# Altium schematic sheet Power.SchDoc(Power)
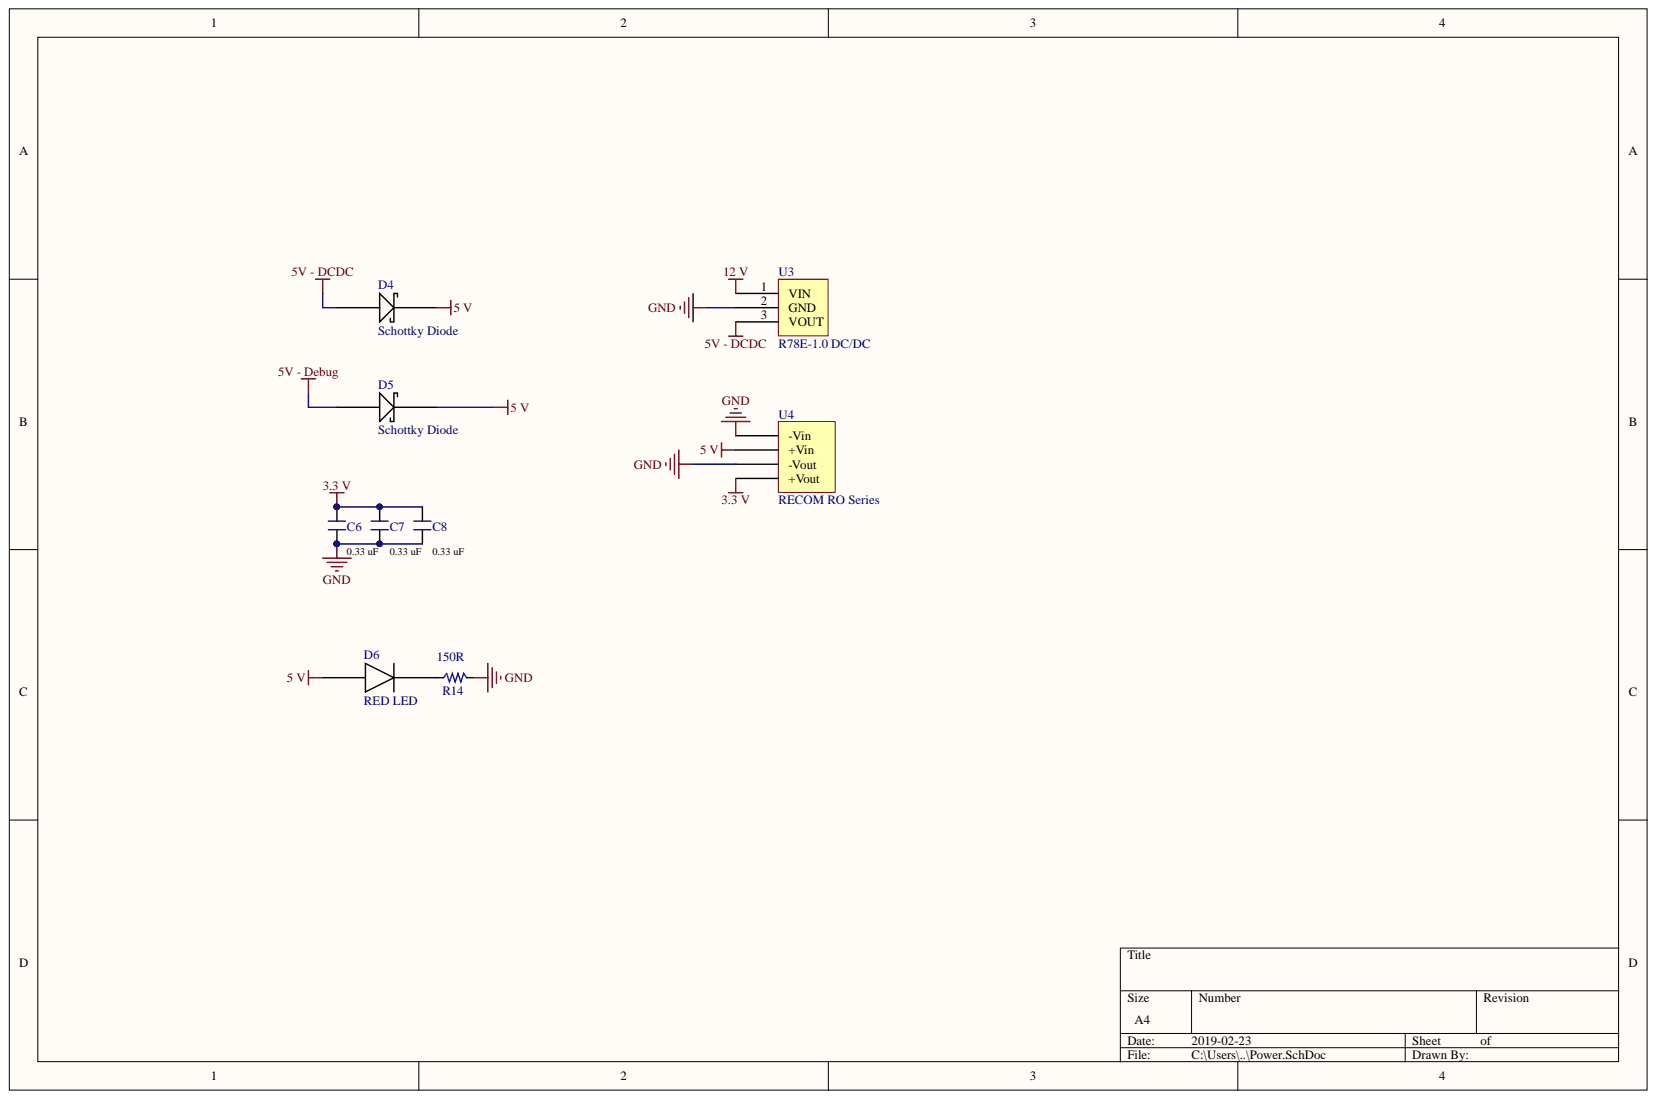
[diagram p0: Power.SchDoc]

## Parts
C6  SCH_GENERIC_CAPACITOR_0603  [PCB_GENERIC_SMD_0603]  (value not drawn)
C7  Capacitance: 0.33 uF  [PCB_GENERIC_SMD_0603]  (hidden comment: SCH_GENERIC_CAPACITOR_0603)
C8  Capacitance: 0.33 uF  [PCB_GENERIC_SMD_0603]  (hidden comment: SCH_GENERIC_CAPACITOR_0603)
D4  Schottky Diode  [ON Semi Schottky Diode - MBRM110LT1G]
D5  Schottky Diode  [ON Semi Schottky Diode - MBRM110LT1G]
D6  RED LED  [SMD_0603_LED]
R14  SCH_GENERIC_RESISTOR_0603  [PCB_GENERIC_SMD_0603]  (value not drawn)
U3  R78E-1.0 DC/DC  [R78-1.0]
U4  RECOM RO Series  [RECOM RO Series 1W DCDC]

## Nets
3.3 V: C6.2 C7.2 C8.2 U4.4(+Vout)
5 V: D4.1 D5.1 D6.1 U4.2(+Vin)
5V - DCDC: D4.2 U3.3
5V - Debug: D5.2
12 V: U3.1
GND: C6.1 C7.1 C8.1 R14.2 U3.2 U4.1(-Vin) U4.3(-Vout)
NetD6_2: D6.2 R14.1

## Library data of the parts
C6 = SCH_GENERIC_CAPACITOR_0603: Generic SMD capacitor 0603; Tolerance: *%; Capacitance: 0.33 uF; Library Name: Generic 0603 Capacitor.SchLib; Component Kind: Standard
C7 C8 = SCH_GENERIC_CAPACITOR_0603: Generic SMD capacitor 0603; Tolerance: *%; Library Name: Generic 0603 Capacitor.SchLib; Component Kind: Standard
D4 D5 = ON Semi Schottky Diode - MBRM110LT1G: Library Name: ON Semi Schottky Diode.SchLib; Component Kind: Standard
D6 = SMD_0603_LED: Library Name: 0603 LED.SchLib; Component Kind: Standard
R14 = SCH_GENERIC_RESISTOR_0603: Generic SMD resistor 0603; Library Name: SCH_GENERIC_RESISTOR_0603.SchLib; Component Kind: Standard
U3 = R78E-1.0: Component Kind: Standard; Library Name: Recom R78E-1.0.SchLib
U4 = RECOM RO Series DCDC Converter: Library Name: RECOM RO Series 1W DCDC.SchLib; Component Kind: Standard
